# Altium schematic sheet ADC Board TOP.SchDoc
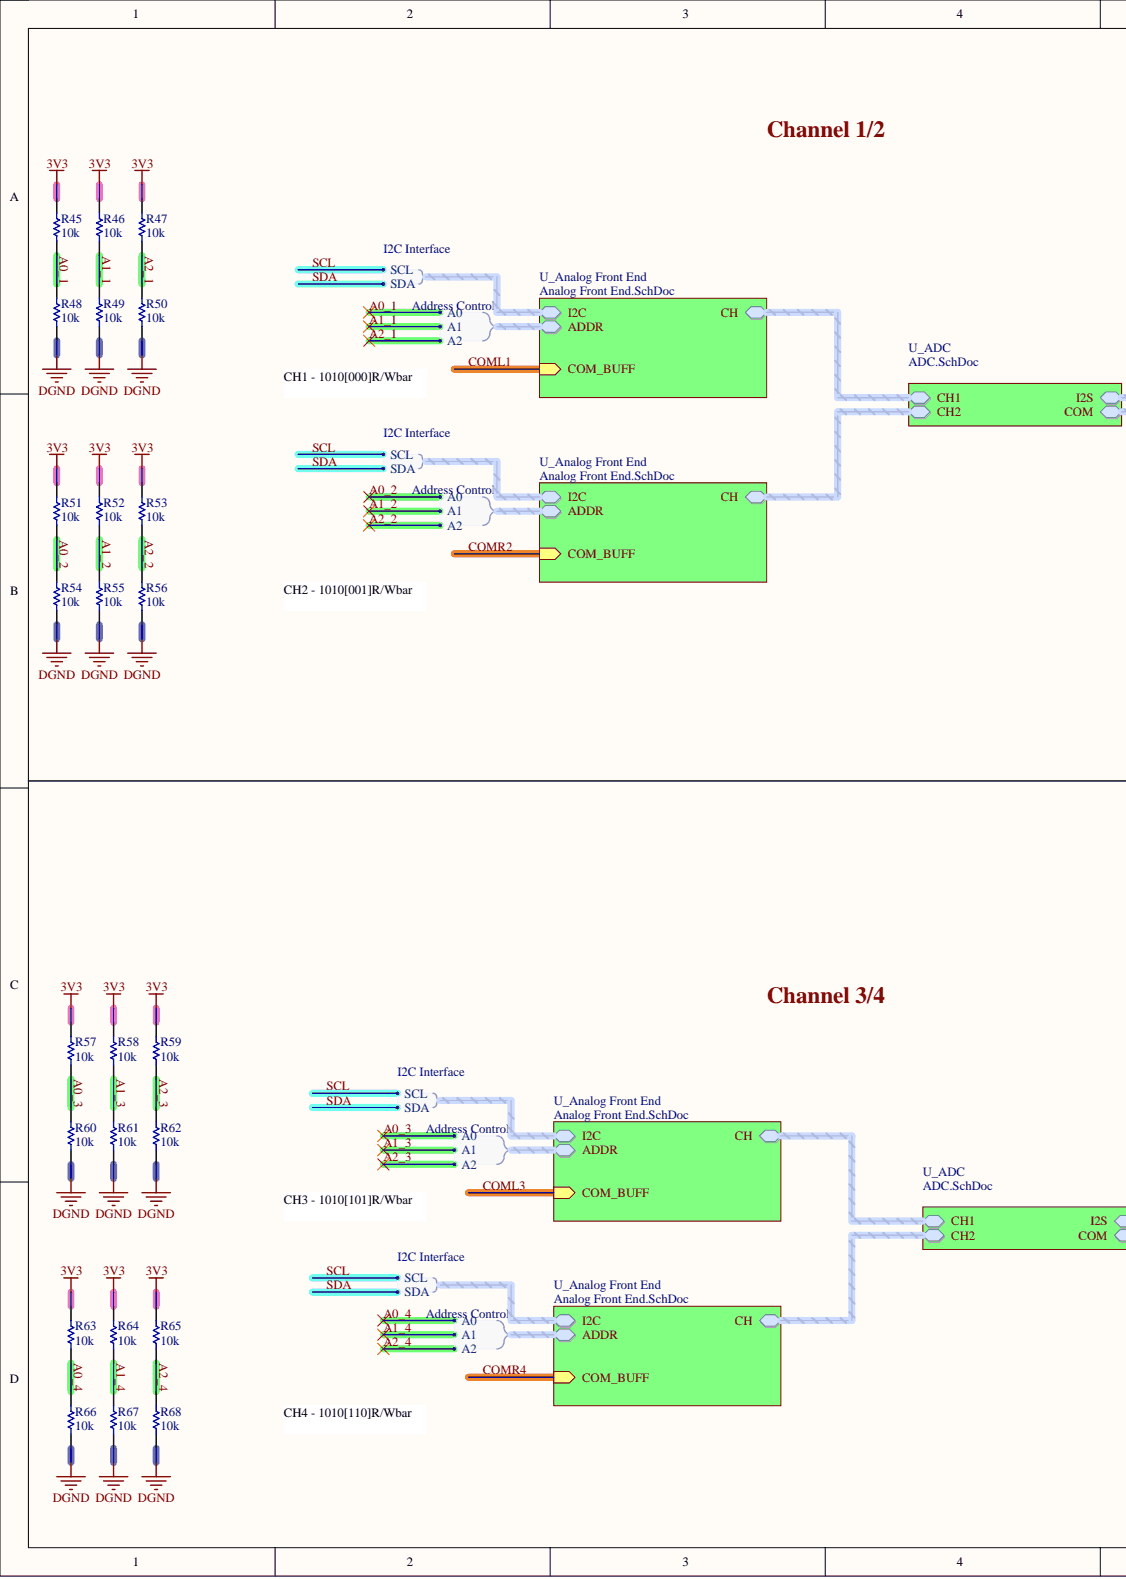
[diagram p0: ADC Board TOP.SchDoc, left]
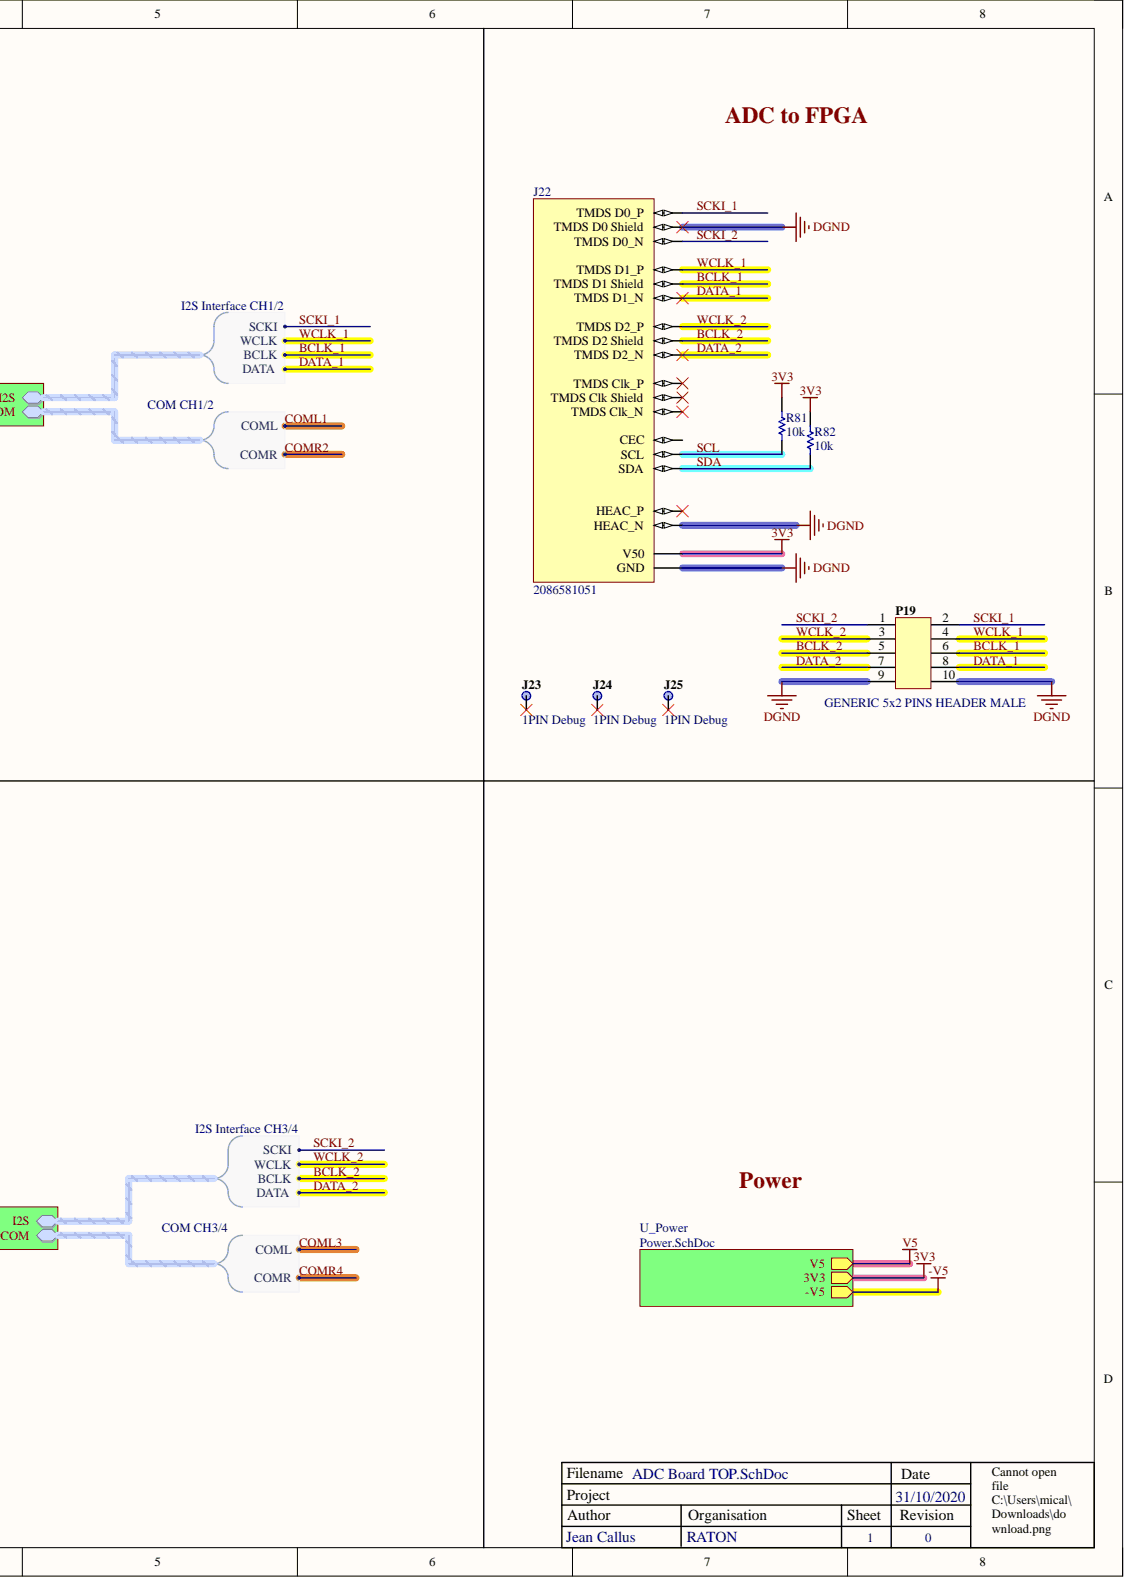
[diagram p1: ADC Board TOP.SchDoc, right]

## Parts
J22  2086581051  [2086581051]
J23  1PIN Debug  [1 Pin Debug Port 0.9mm]
J24  1PIN Debug  [1 Pin Debug Port 0.9mm]
J25  1PIN Debug  [1 Pin Debug Port 0.9mm]
P19  GENERIC 5x2 PINS HEADER MALE  [GENERIC 5PIN 2ROW HEADER 0]
R45  value 10k  [0402-M]  (hidden comment: RES 10k 0402 63mW 5%)
R46  value 10k  [0402-M]  (hidden comment: RES 10k 0402 63mW 5%)
R47  value 10k  [0402-M]  (hidden comment: RES 10k 0402 63mW 5%)
R48  value 10k  [0402-M]  (hidden comment: RES 10k 0402 63mW 5%)
R49  value 10k  [0402-M]  (hidden comment: RES 10k 0402 63mW 5%)
R50  value 10k  [0402-M]  (hidden comment: RES 10k 0402 63mW 5%)
R51  value 10k  [0402-M]  (hidden comment: RES 10k 0402 63mW 5%)
R52  value 10k  [0402-M]  (hidden comment: RES 10k 0402 63mW 5%)
R53  value 10k  [0402-M]  (hidden comment: RES 10k 0402 63mW 5%)
R54  value 10k  [0402-M]  (hidden comment: RES 10k 0402 63mW 5%)
R55  value 10k  [0402-M]  (hidden comment: RES 10k 0402 63mW 5%)
R56  value 10k  [0402-M]  (hidden comment: RES 10k 0402 63mW 5%)
R57  value 10k  [0402-M]  (hidden comment: RES 10k 0402 63mW 5%)
R58  value 10k  [0402-M]  (hidden comment: RES 10k 0402 63mW 5%)
R59  value 10k  [0402-M]  (hidden comment: RES 10k 0402 63mW 5%)
R60  value 10k  [0402-M]  (hidden comment: RES 10k 0402 63mW 5%)
R61  value 10k  [0402-M]  (hidden comment: RES 10k 0402 63mW 5%)
R62  value 10k  [0402-M]  (hidden comment: RES 10k 0402 63mW 5%)
R63  value 10k  [0402-M]  (hidden comment: RES 10k 0402 63mW 5%)
R64  value 10k  [0402-M]  (hidden comment: RES 10k 0402 63mW 5%)
R65  value 10k  [0402-M]  (hidden comment: RES 10k 0402 63mW 5%)
R66  value 10k  [0402-M]  (hidden comment: RES 10k 0402 63mW 5%)
R67  value 10k  [0402-M]  (hidden comment: RES 10k 0402 63mW 5%)
R68  value 10k  [0402-M]  (hidden comment: RES 10k 0402 63mW 5%)
R81  value 10k  [0402-M]  (hidden comment: RES 10k 0402 63mW 5%)
R82  value 10k  [0402-M]  (hidden comment: RES 10k 0402 63mW 5%)

## Nets
3V3: J22.18(V50) R45.2 R46.2 R47.2 R51.2 R52.2 R53.2 R57.2 R58.2 R59.2 R63.2 R64.2 R65.2 R81.2 R82.2
DGND: J22.8(TMDS_D0_Shield) J22.17(GND) J22.19(HEAC_N) P19.9 P19.10 R48.1 R49.1 R50.1 R54.1 R55.1 R56.1 R60.1 R61.1 R62.1 R66.1 R67.1 R68.1
A0_1: R45.1 R48.2
A0_2: R51.1 R54.2
A0_3: R57.1 R60.2
A0_4: R63.1 R66.2
A1_1: R46.1 R49.2
A1_2: R52.1 R55.2
A1_3: R58.1 R61.2
A1_4: R64.1 R67.2
A2_1: R47.1 R50.2
A2_2: R53.1 R56.2
A2_3: R59.1 R62.2
A2_4: R65.1 R68.2
BCLK_1: J22.5(TMDS_D1_Shield) P19.6
BCLK_2: J22.2(TMDS_D2_Shield) P19.5
DATA_1: J22.6(TMDS_D1_N) P19.8
DATA_2: J22.3(TMDS_D2_N) P19.7
SCKI_1: J22.7(TMDS_D0_P) P19.2
SCKI_2: J22.9(TMDS_D0_N) P19.1
SCL: J22.15(SCL) R81.1
SDA: J22.16(SDA) R82.1
WCLK_1: J22.4(TMDS_D1_P) P19.4
WCLK_2: J22.1(TMDS_D2_P) P19.3
NetJ22_10: J22.10(TMDS_Clk_P)
NetJ22_11: J22.11(TMDS_Clk_Shield)
NetJ22_12: J22.12(TMDS_Clk_N)
NetJ22_13: J22.13(CEC)
NetJ22_14: J22.14(HEAC_P)
NetJ23_1: J23.1
NetJ24_1: J24.1
NetJ25_1: J25.1
-V5:
CH1.VIN1N:
CH1.VIN1P:
CH2.VIN1N:
CH2.VIN1P:
COML1:
COML3:
COMR2:
COMR4:
V5:

## Library data of the parts
J22 = 2086581051-2: CONN FEM SMD 19 90Deg HDMI; Manufacturer: Molex; Case/Package: 4 PIN; Component Kind: Standard; Design Item ID: 2086581051; Source: Connector Library.SchLib; Status: Active; Supplier 1: Mouser; Supplier Part Number 1: Mouser; Type: HYBRID; Library Name: University of Malta - MEMENTO
J23-J25 = 1PIN Debug-2: CONN MAL TH 1 0Deg HEADER; Case/Package: 1 PIN; Component Kind: Standard; Design Item ID: 1PIN Debug; Source: Connector Library.SchLib; Status: NC; Type: N/A; Library Name: University of Malta - MEMENTO
P19 = GENERIC 5x2 PINS HEADER MALE-2: CONN MAL TH 5x2 0Deg HEADER; Bin: B3-J5; Case/Package: 2 PIN; Component Kind: Standard; Design Item ID: GENERIC 5x2 PINS HEADER MALE; Manufacturer: Generic; Source: Connector Library.SchLib; Status: Active; Type: TH; Library Name: University of Malta - MEMENTO
R45-R68 R81 R82 = RES 10k 0402 63mW 5%-1: RES-10k-0402-63mW-5%; Manufacturer: Generic; Bin: F1-R7-9; MAPPING: 1(2,1,11.5k); Case/Package: 0402; Component Kind: Standard; Design Item ID: RES 10k 0402 63mW 5%; Source: Resistor Library.SchLib; Status: Active; Type: SMD; Library Name: University of Malta - MEMENTO
